# Altium schematic sheet MOTORES SBUS.SchDoc
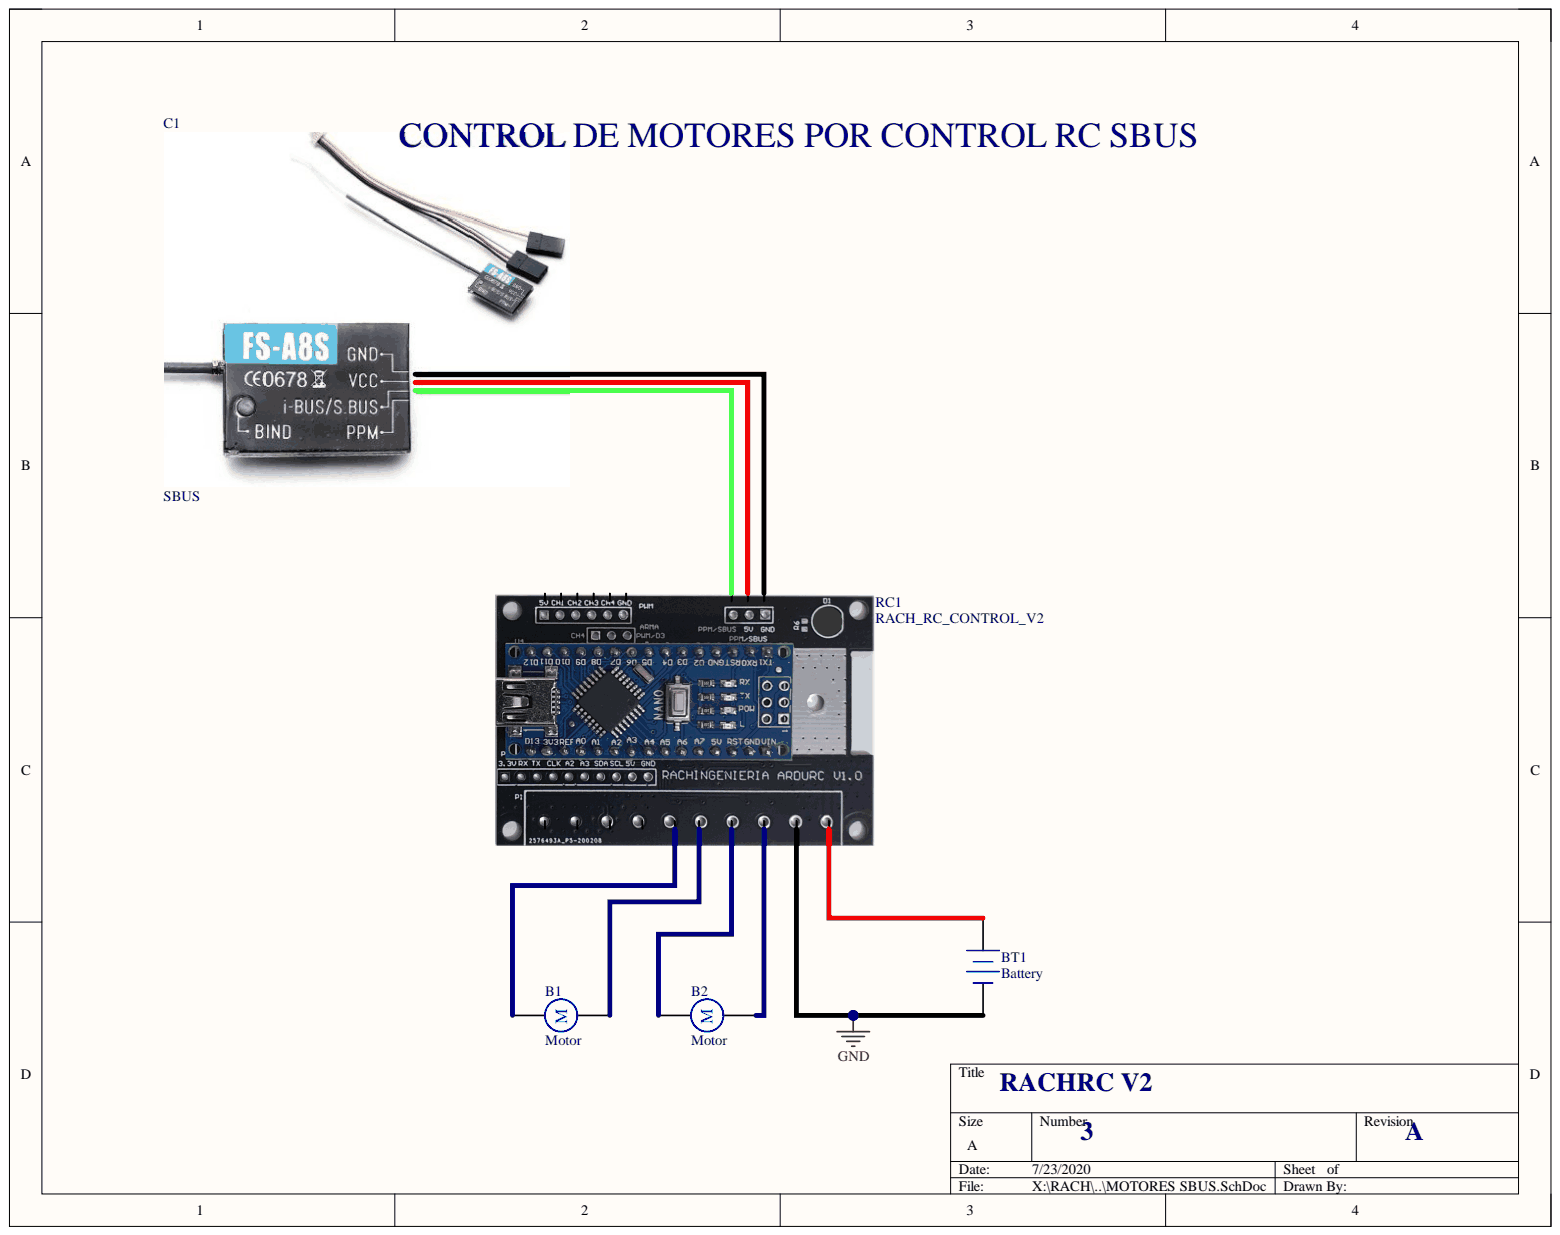
[diagram p0: MOTORES SBUS.SchDoc]

## Parts
B1  Motor  [RB5-10.5]
B2  Motor  [RB5-10.5]
BT1  Battery  [BAT-2]
C1  SBUS
RC1  RACH_RC_CONTROL_V2

## Nets
GND: BT1.2 RC1.19
NetB1_1: B1.1 RC1.15
NetB1_2: B1.2 RC1.16
NetB2_1: B2.1 RC1.17
NetB2_2: B2.2 RC1.18
NetBT1_1: BT1.1 RC1.20
NetC1_1: C1.1 RC1.10
NetC1_2: C1.2 RC1.9
NetC1_3: C1.3 RC1.8

Not connected: C1 4; RC1 2 3 4 5 6 7 11 12 13 14

## Library data of the parts
B1 B2 = Motor: Motor, General Kind; Component Kind: Standard; LatestRevisionDate: 17-Jul-2002; LatestRevisionNote: Re-released for DXP Platform.; Library Name: Miscellaneous Devices.IntLib; PackageDescription: Capacitor (Radial); 2 Leads; PackageReference: RB5-10.5; Published: 24-Mar-1999; Publisher: Altium Limited
BT1 = Battery: Multicell Battery; Component Kind: Standard; LatestRevisionDate: 17-Jul-2002; LatestRevisionNote: Re-released for DXP Platform.; Library Name: Miscellaneous Devices.IntLib; PackageDescription: Battery; 2 Leads; PackageReference: BAT-2; Published: 24-Mar-1999; Publisher: Altium Limited
C1 = SBUS: Component Kind: Standard; Library Name: Componentes.SchLib
RC1 = RACH_RC_CONTROL_V2: Component Kind: Standard; Library Name: Componentes.SchLib
